# Altium schematic sheet GateDriverPowerSupply.SchDoc
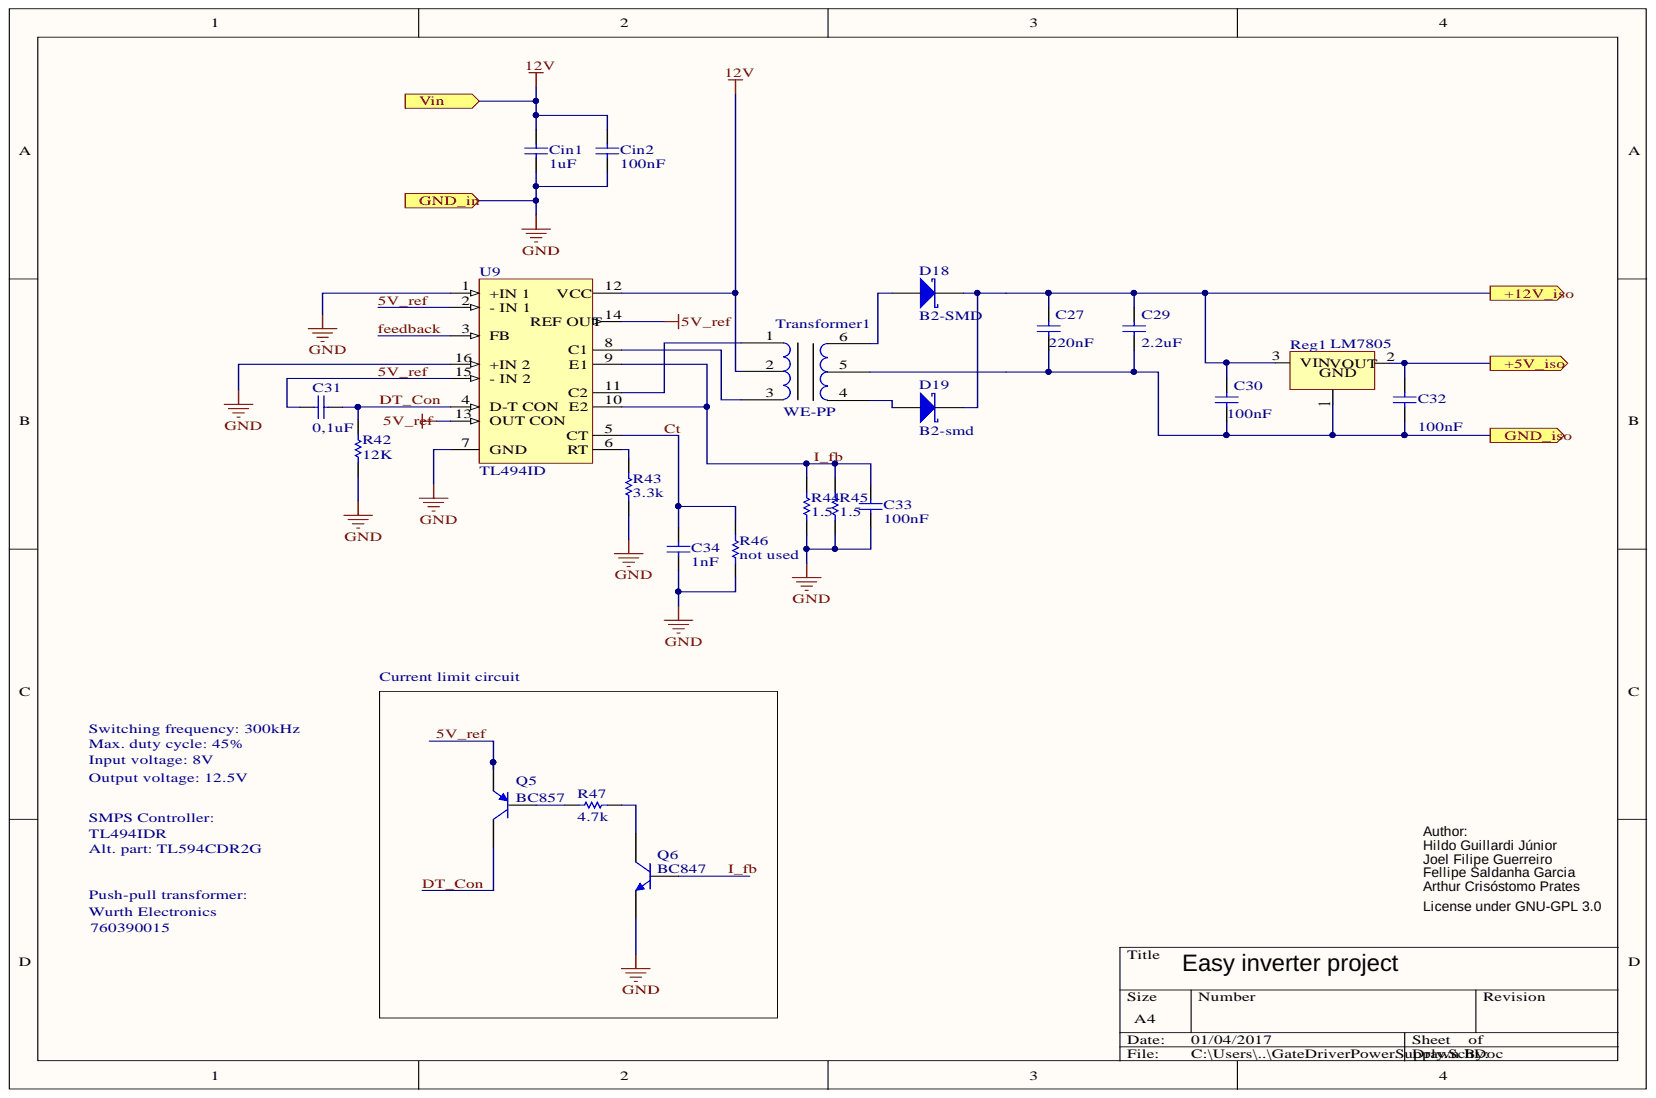
[diagram p0: GateDriverPowerSupply.SchDoc]

## Parts
C27  Capacitor_nao_polarizado  [0805_W]  (value not drawn)
C29  value 2.2uF  [0805_W]  (hidden comment: Capacitor_nao_polarizado)
C30  value 100nF  [0805_W]  (hidden comment: Capacitor_nao_polarizado)
C31  value 0,1uF  [0805_W]  (hidden comment: Cap_0805)
C32  value 100nF  [0805_W]  (hidden comment: Capacitor_nao_polarizado)
C33  value 100nF  [0805_W]  (hidden comment: Cap_0805)
C34  value 1nF  [0805_W]  (hidden comment: Cap_0805)
Cin1  value 1uF  [0805_W]  (hidden comment: Cap_0805)
Cin2  value 100nF  [0805_W]  (hidden comment: Cap_0805)
D18  B2-SMD  [SOD-123]
D19  B2-smd  [SOD-123]
Q5  BC857  [SOT-23-TT3_N]
Q6  BC847  [SOT-23-TT3_N]
R42  value 12K  [0805_W]  (hidden comment: Res_0805)
R43  value 3.3k  [0805_W]  (hidden comment: Res_0805)
R44  value 1.5  [0805_W]  (hidden comment: Res_0805)
R45  value 1.5  [0805_W]  (hidden comment: Res_0805)
R46  value not used  [0805_W]  (hidden comment: Res_0805)
R47  value 4.7k  [0805_W]  (hidden comment: Res_0805)
Reg1  LM7805  [LM7805]
Transformer1  WE-PP  [Trafo]
U9  TL494ID  [SOIC16_N]

## Ports
+5V_ISO, +12V_ISO, GND_IN, GND_ISO, VIN

## Nets
12V: Cin1.1 Cin2.1 Transformer1.2 U9.12 ; port VIN
GND: C33.1 C34.2 Cin1.2 Cin2.2 Q6.2 R42.1 R43.1 R44.1 R45.1 R46.1 U9.1 U9.7 U9.16 ; port GND_IN
5V_REF: C31.1 Q5.2 U9.2 U9.13 U9.14 U9.15
CT: C34.1 R46.2 U9.5
DT_CON: C31.2 Q5.3 R42.2 U9.4
FEEDBACK: U9.3
I_FB: C33.2 Q6.1 R44.2 R45.2 U9.9 U9.10
NetC27_1: C27.1 C29.1 C30.1 D18.2 D19.2 Reg1.3 ; port +12V_ISO
NetC27_2: C27.2 C29.2 C30.2 C32.2 Reg1.1 Transformer1.5 ; port GND_ISO
NetC32_1: C32.1 Reg1.2 ; port +5V_ISO
NetD18_1: D18.1 Transformer1.6
NetD19_1: D19.1 Transformer1.4
NetQ5_1: Q5.1 R47.2
NetQ6_3: Q6.3 R47.1
NetR43_2: R43.2 U9.6
NetTransformer1_1: Transformer1.1 U9.11
NetTransformer1_3: Transformer1.3 U9.8

## Library data of the parts
C27 = Capacitor_nao_polarizado: Capacitor nao polarizado; Value: 220nF; Component Kind: Standard; Library Name: Sch_LCEE.SchLib
C29 C30 C32 = Capacitor_nao_polarizado: Capacitor nao polarizado; Component Kind: Standard; Library Name: Sch_LCEE.SchLib
C31 C33 C34 Cin1 Cin2 = Cap_0805: Capacitor; Component Kind: Standard; LatestRevisionDate: 17-Jul-2002; LatestRevisionNote: Re-released for DXP Platform.; PackageReference: RAD-0.3; Published: 8-Jun-2000; Signal Integrity: Cap; Simulation: CAP; Library Name: Inversor_LCEE.SchLib; Publisher: Altium Limited
D18 D19 = Schottky: Diodo Schottky; Component Kind: Standard; Library Name: Sch_LCEE.SchLib
Q5 = BC857: PNP General-purpose Transistor; Component Kind: Standard; ComponentLink1Description: Manufacturer Link; ComponentLink1URL: http://www.semiconductors.philips.com/; ComponentLink2Description: Datasheet; ComponentLink2URL: http://www.semiconductors.philips.com/acrobat/datasheets/BC856_BC857_BC858_6.pdf; DatasheetVersion: 4-Feb-2002; LatestRevisionDate: 12-Dec-2006; LatestRevisionNote: IPC-7351 Footprint Added.; Library Name: Philips Discrete BJT - Low Power.IntLib; PackageDescription: 3-Pin SOT-23 Package 0.95 mm Pitch, 2.3 mm Lead Span; PackageReference: SOT23; PackageVersion: May-1999; Published: 17-Sep-2003; Signal Integrity: BC857; Simulation: QBC857; Publisher: Altium Limited
Q6 = BC847: NPN General-purpose Transistor; Component Kind: Standard; ComponentLink1Description: Manufacturer Link; ComponentLink1URL: http://www.semiconductors.philips.com/; ComponentLink2Description: Datasheet; ComponentLink2URL: http://www.semiconductors.philips.com/acrobat/datasheets/BC846_BC847_BC848_5.pdf; DatasheetVersion: 4-Feb-2002; LatestRevisionDate: 12-Dec-2006; LatestRevisionNote: IPC-7351 Footprint Added.; Library Name: Philips Discrete BJT - Low Power.IntLib; PackageDescription: 3-Pin SOT-23 Package 0.95 mm Pitch, 2.3 mm Lead Span; PackageReference: SOT23; PackageVersion: May-1999; Published: 17-Sep-2003; Signal Integrity: BC847; Simulation: QBC847; Publisher: Altium Limited
R42-R47 = Res_0805: Resistor; Manufacturer: Generic Components; Class: Passive; Component Kind: Standard; Published: 08/06/2000; Signal Integrity: Res1; Simulation: RESISTOR; SubClass: Resistor; Library Name: Inversor_LCEE.SchLib; Publisher: Altium Hobart Technology Centre
Transformer1 = Trafo-TI: Component Kind: Standard; Library Name: Sch_LCEE.SchLib
U9 = TL494ID: Pulse-Width-Modulation Control Circuit; Code_IPC: SOIC127P600-16; Component Kind: Standard; ComponentLink1Description: Manufacturer Link; ComponentLink1URL: http://www.ti.com/; DatasheetVersion: Oct-1988; LatestRevisionDate: 13-Apr-2006; LatestRevisionNote: IPC-7351 Footprint Added.; Library Name: TI Power Mgt Power Controller.IntLib; PackageDescription: 16-Pin Small Outline Integrated Circuit 1.27 mm Pitch; PackageReference: D016; PackageVersion: Jan-1998; PCB3D: D016; Published: 15-Apr-2002; Publisher: Altium Limited
Reg1 = LM7805: Component Kind: Standard; Library Name: Sch_LCEE.SchLib
